# Altium schematic sheet 05 - Motor Drivers.SchDoc
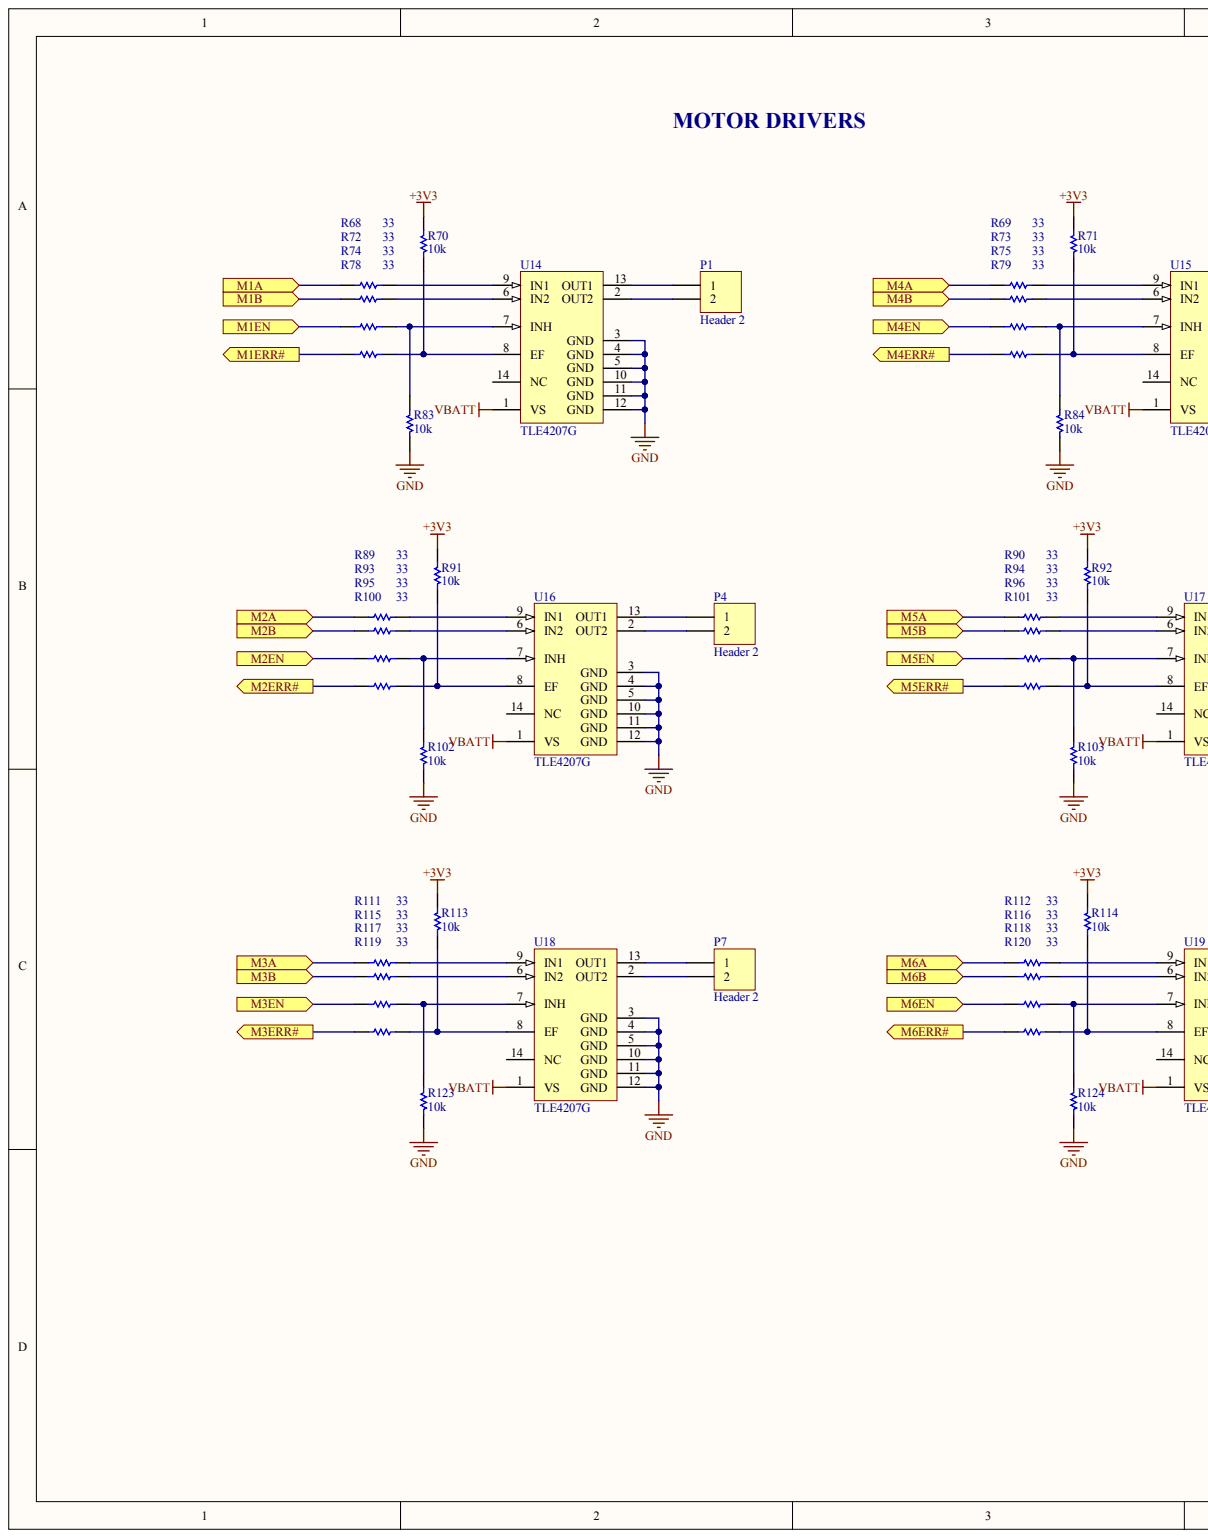
[diagram p0: 05 - Motor Drivers.SchDoc, left]
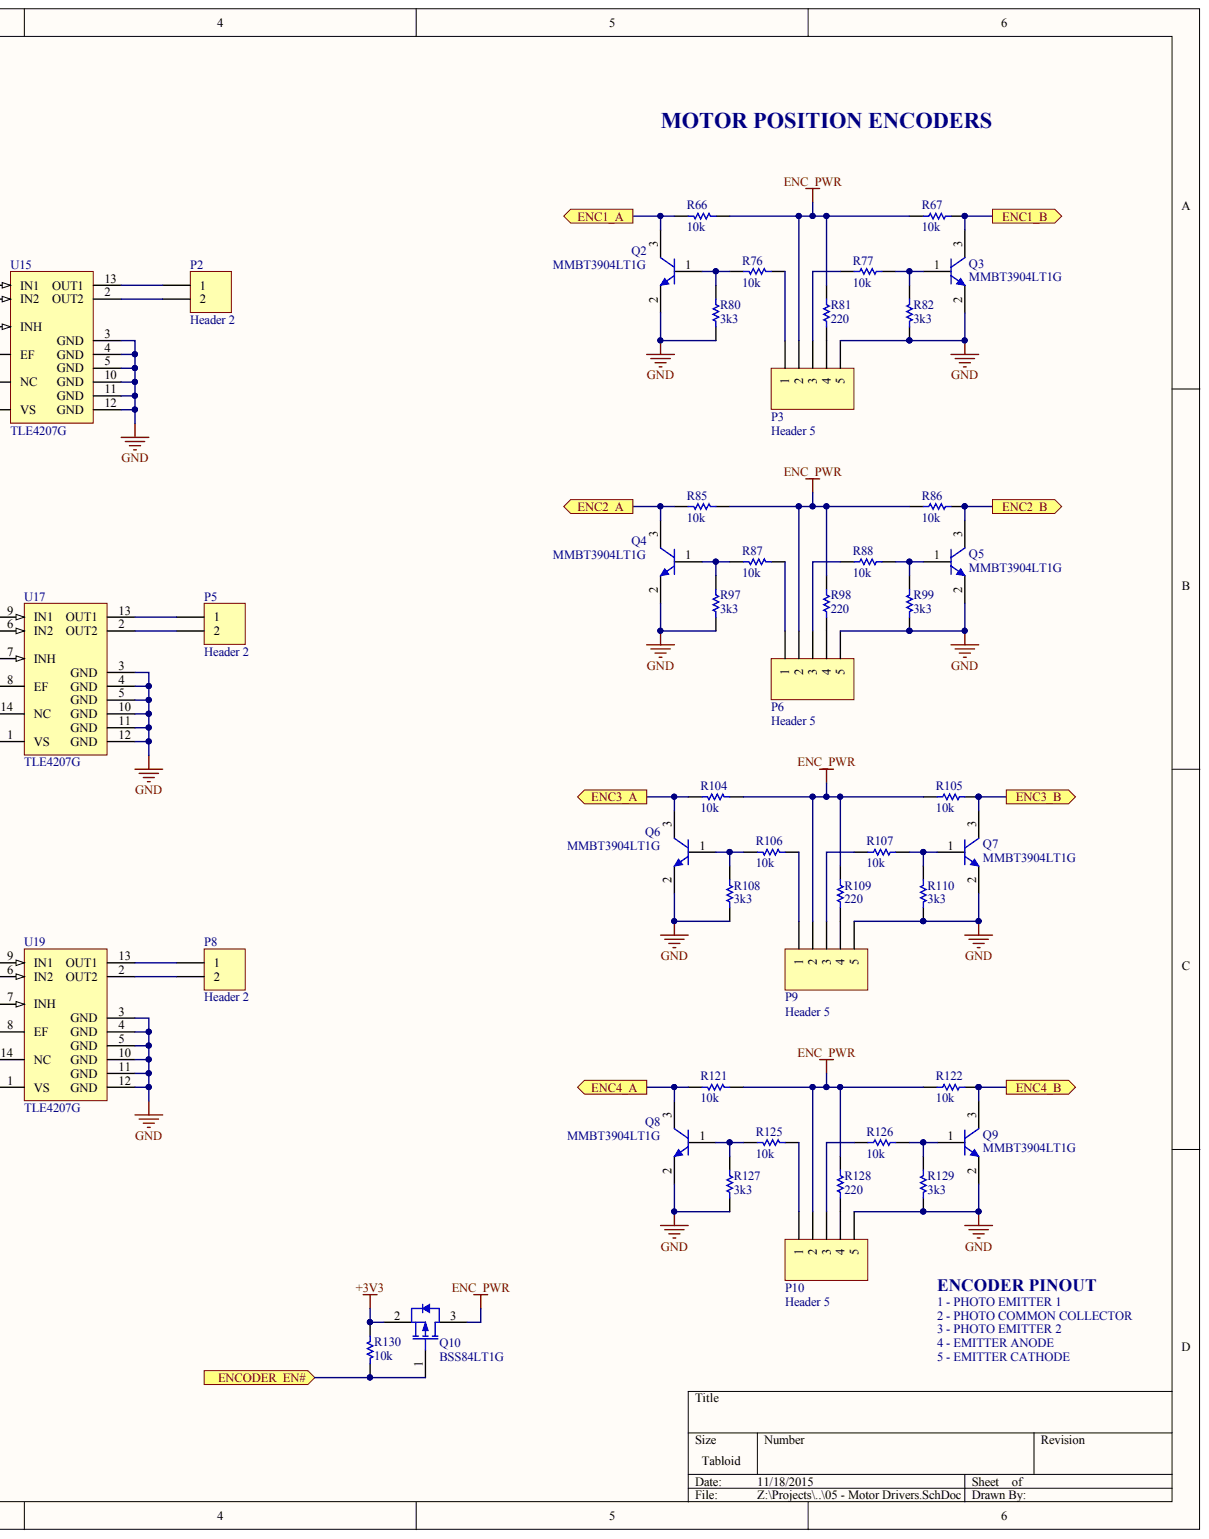
[diagram p1: 05 - Motor Drivers.SchDoc, right]

## Parts
P1  Header 2  [HDR1X2]
P2  Header 2  [HDR1X2]
P3  Header 5  [HDR1X5]
P4  Header 2  [HDR1X2]
P5  Header 2  [HDR1X2]
P6  Header 5  [HDR1X5]
P7  Header 2  [HDR1X2]
P8  Header 2  [HDR1X2]
P9  Header 5  [HDR1X5]
P10  Header 5  [HDR1X5]
Q2  MMBT3904LT1G  [ONSC-SOT-23-3-318-08_V]
Q3  MMBT3904LT1G  [ONSC-SOT-23-3-318-08_V]
Q4  MMBT3904LT1G  [ONSC-SOT-23-3-318-08_V]
Q5  MMBT3904LT1G  [ONSC-SOT-23-3-318-08_V]
Q6  MMBT3904LT1G  [ONSC-SOT-23-3-318-08_V]
Q7  MMBT3904LT1G  [ONSC-SOT-23-3-318-08_V]
Q8  MMBT3904LT1G  [ONSC-SOT-23-3-318-08_V]
Q9  MMBT3904LT1G  [ONSC-SOT-23-3-318-08_V]
Q10  BSS84LT1G  [ONSC-SOT-23-3-318-08_V]
R66  value 10k  [RESC0805(2012)_M]  (hidden comment: 10K 1% 0805(2012))
R67  value 10k  [RESC0805(2012)_M]  (hidden comment: 10K 1% 0805(2012))
R68  value 33  [RESC0805(2012)_L]  (hidden comment: 33R 1% 0805(2012))
R69  value 33  [RESC0805(2012)_L]  (hidden comment: 33R 1% 0805(2012))
R70  value 10k  [RESC0805(2012)_M]  (hidden comment: 10K 1% 0805(2012))
R71  value 10k  [RESC0805(2012)_M]  (hidden comment: 10K 1% 0805(2012))
R72  value 33  [RESC0805(2012)_L]  (hidden comment: 33R 1% 0805(2012))
R73  value 33  [RESC0805(2012)_L]  (hidden comment: 33R 1% 0805(2012))
R74  value 33  [RESC0805(2012)_L]  (hidden comment: 33R 1% 0805(2012))
R75  value 33  [RESC0805(2012)_L]  (hidden comment: 33R 1% 0805(2012))
R76  value 10k  [RESC0805(2012)_M]  (hidden comment: 10K 1% 0805(2012))
R77  value 10k  [RESC0805(2012)_M]  (hidden comment: 10K 1% 0805(2012))
R78  value 33  [RESC0805(2012)_L]  (hidden comment: 33R 1% 0805(2012))
R79  value 33  [RESC0805(2012)_L]  (hidden comment: 33R 1% 0805(2012))
R80  value 3k3  [RESC0805(2012)_L]  (hidden comment: 3K3 1% 0805(2012))
R81  value 220  [RESC0805(2012)_M]  (hidden comment: 220R 1% 0805(2012))
R82  value 3k3  [RESC0805(2012)_L]  (hidden comment: 3K3 1% 0805(2012))
R83  value 10k  [RESC0805(2012)_M]  (hidden comment: 10K 1% 0805(2012))
R84  value 10k  [RESC0805(2012)_M]  (hidden comment: 10K 1% 0805(2012))
R85  value 10k  [RESC0805(2012)_M]  (hidden comment: 10K 1% 0805(2012))
R86  value 10k  [RESC0805(2012)_M]  (hidden comment: 10K 1% 0805(2012))
R87  value 10k  [RESC0805(2012)_M]  (hidden comment: 10K 1% 0805(2012))
R88  value 10k  [RESC0805(2012)_M]  (hidden comment: 10K 1% 0805(2012))
R89  value 33  [RESC0805(2012)_L]  (hidden comment: 33R 1% 0805(2012))
R90  value 33  [RESC0805(2012)_L]  (hidden comment: 33R 1% 0805(2012))
R91  value 10k  [RESC0805(2012)_M]  (hidden comment: 10K 1% 0805(2012))
R92  value 10k  [RESC0805(2012)_M]  (hidden comment: 10K 1% 0805(2012))
R93  value 33  [RESC0805(2012)_L]  (hidden comment: 33R 1% 0805(2012))
R94  value 33  [RESC0805(2012)_L]  (hidden comment: 33R 1% 0805(2012))
R95  value 33  [RESC0805(2012)_L]  (hidden comment: 33R 1% 0805(2012))
R96  value 33  [RESC0805(2012)_L]  (hidden comment: 33R 1% 0805(2012))
R97  value 3k3  [RESC0805(2012)_L]  (hidden comment: 3K3 1% 0805(2012))
R98  value 220  [RESC0805(2012)_M]  (hidden comment: 220R 1% 0805(2012))
R99  value 3k3  [RESC0805(2012)_L]  (hidden comment: 3K3 1% 0805(2012))
R100  value 33  [RESC0805(2012)_L]  (hidden comment: 33R 1% 0805(2012))
R101  value 33  [RESC0805(2012)_L]  (hidden comment: 33R 1% 0805(2012))
R102  value 10k  [RESC0805(2012)_M]  (hidden comment: 10K 1% 0805(2012))
R103  value 10k  [RESC0805(2012)_M]  (hidden comment: 10K 1% 0805(2012))
R104  value 10k  [RESC0805(2012)_M]  (hidden comment: 10K 1% 0805(2012))
R105  value 10k  [RESC0805(2012)_M]  (hidden comment: 10K 1% 0805(2012))
R106  value 10k  [RESC0805(2012)_M]  (hidden comment: 10K 1% 0805(2012))
R107  value 10k  [RESC0805(2012)_M]  (hidden comment: 10K 1% 0805(2012))
R108  value 3k3  [RESC0805(2012)_L]  (hidden comment: 3K3 1% 0805(2012))
R109  value 220  [RESC0805(2012)_M]  (hidden comment: 220R 1% 0805(2012))
R110  value 3k3  [RESC0805(2012)_L]  (hidden comment: 3K3 1% 0805(2012))
R111  value 33  [RESC0805(2012)_L]  (hidden comment: 33R 1% 0805(2012))
R112  value 33  [RESC0805(2012)_L]  (hidden comment: 33R 1% 0805(2012))
R113  value 10k  [RESC0805(2012)_M]  (hidden comment: 10K 1% 0805(2012))
R114  value 10k  [RESC0805(2012)_M]  (hidden comment: 10K 1% 0805(2012))
R115  value 33  [RESC0805(2012)_L]  (hidden comment: 33R 1% 0805(2012))
R116  value 33  [RESC0805(2012)_L]  (hidden comment: 33R 1% 0805(2012))
R117  value 33  [RESC0805(2012)_L]  (hidden comment: 33R 1% 0805(2012))
R118  value 33  [RESC0805(2012)_L]  (hidden comment: 33R 1% 0805(2012))
R119  value 33  [RESC0805(2012)_L]  (hidden comment: 33R 1% 0805(2012))
R120  value 33  [RESC0805(2012)_L]  (hidden comment: 33R 1% 0805(2012))
R121  value 10k  [RESC0805(2012)_M]  (hidden comment: 10K 1% 0805(2012))
R122  value 10k  [RESC0805(2012)_M]  (hidden comment: 10K 1% 0805(2012))
R123  value 10k  [RESC0805(2012)_M]  (hidden comment: 10K 1% 0805(2012))
R124  value 10k  [RESC0805(2012)_M]  (hidden comment: 10K 1% 0805(2012))
R125  value 10k  [RESC0805(2012)_M]  (hidden comment: 10K 1% 0805(2012))
R126  value 10k  [RESC0805(2012)_M]  (hidden comment: 10K 1% 0805(2012))
R127  value 3k3  [RESC0805(2012)_L]  (hidden comment: 3K3 1% 0805(2012))
R128  value 220  [RESC0805(2012)_M]  (hidden comment: 220R 1% 0805(2012))
R129  value 3k3  [RESC0805(2012)_L]  (hidden comment: 3K3 1% 0805(2012))
R130  value 10k  [RESC0805(2012)_M]  (hidden comment: 10K 1% 0805(2012))
U14  TLE4207G  [INF-PG-DSO-14-22_N]
U15  TLE4207G  [INF-PG-DSO-14-22_N]
U16  TLE4207G  [INF-PG-DSO-14-22_N]
U17  TLE4207G  [INF-PG-DSO-14-22_N]
U18  TLE4207G  [INF-PG-DSO-14-22_N]
U19  TLE4207G  [INF-PG-DSO-14-22_N]

## Ports
ENC1_A, ENC1_B, ENC2_A, ENC2_B, ENC3_A, ENC3_B, ENC4_A, ENC4_B, ENCODER_EN#, M1A, M1B, M1EN, M1ERR#, M2A, M2B, M2EN, M2ERR#, M3A, M3B, M3EN, M3ERR#, M4A, M4B, M4EN, M4ERR#, M5A, M5B, M5EN, M5ERR#, M6A, M6B, M6EN, M6ERR#

## Nets
+3V3: Q10.2 R70.1 R71.1 R91.1 R92.1 R113.1 R114.1 R130.1
ENC_PWR: P3.2 P6.2 P9.2 P10.2 Q10.3 R66.2 R67.1 R81.2 R85.2 R86.1 R98.2 R104.2 R105.1 R109.2 R121.2 R122.1 R128.2
GND: P3.5 P6.5 P9.5 P10.5 Q2.2 Q3.2 Q4.2 Q5.2 Q6.2 Q7.2 Q8.2 Q9.2 R80.2 R82.2 R83.1 R84.1 R97.2 R99.2 R102.1 R103.1 R108.2 R110.2 R123.1 R124.1 R127.2 R129.2 U14.3(GND) U14.4(GND) U14.5(GND) U14.10(GND) U14.11(GND) U14.12(GND) U15.3(GND) U15.4(GND) U15.5(GND) U15.10(GND) U15.11(GND) U15.12(GND) U16.3(GND) U16.4(GND) U16.5(GND) U16.10(GND) U16.11(GND) U16.12(GND) U17.3(GND) U17.4(GND) U17.5(GND) U17.10(GND) U17.11(GND) U17.12(GND) U18.3(GND) U18.4(GND) U18.5(GND) U18.10(GND) U18.11(GND) U18.12(GND) U19.3(GND) U19.4(GND) U19.5(GND) U19.10(GND) U19.11(GND) U19.12(GND)
VBATT: U14.1(VS) U15.1(VS) U16.1(VS) U17.1(VS) U18.1(VS) U19.1(VS)
ENC1_A: Q2.3 R66.1 ; port ENC1_A
ENC1_B: Q3.3 R67.2 ; port ENC1_B
ENC2_A: Q4.3 R85.1 ; port ENC2_A
ENC2_B: Q5.3 R86.2 ; port ENC2_B
ENC3_A: Q6.3 R104.1 ; port ENC3_A
ENC3_B: Q7.3 R105.2 ; port ENC3_B
ENC4_A: Q8.3 R121.1 ; port ENC4_A
ENC4_B: Q9.3 R122.2 ; port ENC4_B
ENCODER_EN#: Q10.1 R130.2 ; port ENCODER_EN#
M1A: R68.1 ; port M1A
M1B: R72.1 ; port M1B
M1EN: R74.1 ; port M1EN
M1ERR#: R78.1 ; port M1ERR#
M2A: R89.1 ; port M2A
M2B: R93.1 ; port M2B
M2EN: R95.1 ; port M2EN
M2ERR#: R100.1 ; port M2ERR#
M3A: R111.1 ; port M3A
M3B: R115.1 ; port M3B
M3EN: R117.1 ; port M3EN
M3ERR#: R119.1 ; port M3ERR#
M4A: R69.1 ; port M4A
M4B: R73.1 ; port M4B
M4EN: R75.1 ; port M4EN
M4ERR#: R79.1 ; port M4ERR#
M5A: R90.1 ; port M5A
M5B: R94.1 ; port M5B
M5EN: R96.1 ; port M5EN
M5ERR#: R101.1 ; port M5ERR#
M6A: R112.1 ; port M6A
M6B: R116.1 ; port M6B
M6EN: R118.1 ; port M6EN
M6ERR#: R120.1 ; port M6ERR#
NetP1_1: P1.1 U14.13(OUT1)
NetP1_2: P1.2 U14.2(OUT2)
NetP2_1: P2.1 U15.13(OUT1)
NetP2_2: P2.2 U15.2(OUT2)
NetP3_1: P3.1 R76.1
NetP3_3: P3.3 R77.1
NetP3_4: P3.4 R81.1
NetP4_1: P4.1 U16.13(OUT1)
NetP4_2: P4.2 U16.2(OUT2)
NetP5_1: P5.1 U17.13(OUT1)
NetP5_2: P5.2 U17.2(OUT2)
NetP6_1: P6.1 R87.1
NetP6_3: P6.3 R88.1
NetP6_4: P6.4 R98.1
NetP7_1: P7.1 U18.13(OUT1)
NetP7_2: P7.2 U18.2(OUT2)
NetP8_1: P8.1 U19.13(OUT1)
NetP8_2: P8.2 U19.2(OUT2)
NetP9_1: P9.1 R106.1
NetP9_3: P9.3 R107.1
NetP9_4: P9.4 R109.1
NetP10_1: P10.1 R125.1
NetP10_3: P10.3 R126.1
NetP10_4: P10.4 R128.1
NetQ2_1: Q2.1 R76.2 R80.1
NetQ3_1: Q3.1 R77.2 R82.1
NetQ4_1: Q4.1 R87.2 R97.1
NetQ5_1: Q5.1 R88.2 R99.1
NetQ6_1: Q6.1 R106.2 R108.1
NetQ7_1: Q7.1 R107.2 R110.1
NetQ8_1: Q8.1 R125.2 R127.1
NetQ9_1: Q9.1 R126.2 R129.1
NetR68_2: R68.2 U14.9(IN1)
NetR69_2: R69.2 U15.9(IN1)
NetR70_2: R70.2 R78.2 U14.8(EF)
NetR71_2: R71.2 R79.2 U15.8(EF)
NetR72_2: R72.2 U14.6(IN2)
NetR73_2: R73.2 U15.6(IN2)
NetR74_2: R74.2 R83.2 U14.7(INH)
NetR75_2: R75.2 R84.2 U15.7(INH)
NetR89_2: R89.2 U16.9(IN1)
NetR90_2: R90.2 U17.9(IN1)
NetR91_2: R91.2 R100.2 U16.8(EF)
NetR92_2: R92.2 R101.2 U17.8(EF)
NetR93_2: R93.2 U16.6(IN2)
NetR94_2: R94.2 U17.6(IN2)
NetR95_2: R95.2 R102.2 U16.7(INH)
NetR96_2: R96.2 R103.2 U17.7(INH)
NetR111_2: R111.2 U18.9(IN1)
NetR112_2: R112.2 U19.9(IN1)
NetR113_2: R113.2 R119.2 U18.8(EF)
NetR114_2: R114.2 R120.2 U19.8(EF)
NetR115_2: R115.2 U18.6(IN2)
NetR116_2: R116.2 U19.6(IN2)
NetR117_2: R117.2 R123.2 U18.7(INH)
NetR118_2: R118.2 R124.2 U19.7(INH)

Not connected: U14 14(NC); U15 14(NC); U16 14(NC); U17 14(NC); U18 14(NC); U19 14(NC)

## Library data of the parts
P1 P2 P4 P5 P7 P8 = Header 2: Header, 2-Pin; Component Kind: Standard; LatestRevisionDate: 17-Jul-2002; LatestRevisionNote: Re-released for DXP Platform.; Library Name: Miscellaneous Connectors.IntLib; Signal Integrity: Connector; Publisher: Altium Limited
P3 P6 P9 P10 = Header 5: Header, 5-Pin; Component Kind: Standard; LatestRevisionDate: 17-Jul-2002; LatestRevisionNote: Re-released for DXP Platform.; Library Name: Miscellaneous Connectors.IntLib; Signal Integrity: Connector; Publisher: Altium Limited
Q2-Q9 = CMP-1048-00631-1: General Purpose Transistor, NPN Silicon, 3-Pin SOT-23, Pb-Free, Tape and Reel; Manufacturer: On Semiconductor; fT Min (MHz): 300; IC Continuous (A): 0.2; Component Kind: Standard; ComponentLink1Description: Manufacturer URL; ComponentLink1URL: http://www.onsemi.com/; ComponentLink2Description: Datasheet; ComponentLink2URL: http://www.onsemi.cn/pub_link/Collateral/MMBT3904LT1-D.PDF; ComponentLink3Description: Package Specification; ComponentLink3URL: http://www.onsemi.cn/pub_link/Collateral/318-08.PDF; DatasheetVersion: Rev. 12; Library Name: Altium Content Vault; Mounting Technology: Surface Mount; PackageDescription: 3-Pin Small Outline Transistor, Body 2.92 x 1.3 mm, Pitch 0.95 mm; PackageReference: SOT-23-3-318-08; PackageVersion: Rev. AP, 11/2009; Packing: Tape and Reel; PartNumber: MMBT3904LT1G; Polarity: NPN; RoHS: TRUE
Q10 = CMP-1058-00762-1: Power MOSFET Single P-Channel, -50 V, 10 Ohm, 3-Pin SOT-23, Pb-Free, Tape and Reel; Manufacturer: On Semiconductor; Channel Polarity: P Channel; Ciss Typ (pF): 36; Coss Typ (pF): 17; Crss Typ (pF): 6.5; ID Max (A): 0.13; PD Max (W): 0.225; V(BR)DSS Min (V): 50; VGS(th) Max (V): 2; VGS Max (V): 20; Component Kind: Standard; ComponentLink1Description: Manufacturer URL; ComponentLink1URL: http://www.onsemi.com/; ComponentLink2Description: Datasheet; ComponentLink2URL: http://www.onsemi.com/pub_link/Collateral/BSS84LT1-D.PDF; ComponentLink3Description: Package Specification; ComponentLink3URL: http://www.onsemi.cn/pub_link/Collateral/318-08.PDF; Configuration: Single; DatasheetVersion: Rev. 8; Library Name: Altium Inc., Content Vault; Mounting Technology: Surface Mount; PackageDescription: 3-Pin Small Outline Transistor, Body 2.92 x 1.3 mm, Pitch 0.95 mm; PackageReference: SOT-23-3-318-08; Package Type: SOT-23-3; PackageVersion: Rev. AP, 11/2009; Packing: Tape and Reel; PartNumber: BSS84LT1G; RoHS: Pb-Free
R66 R67 R70 R71 R76 R77 R83-R88 R91 R92 R102-R107 R113 R114 R121-R126 R130 = CMP-1013-00623-1: 10K 0.125W 1% 0805 (2012 Metric)  SMD; Case-EIA: 0805; Case-Metric: 2012; Component Kind: Standard; Library Name: Altium Content Vault; Power: 0.125 W; Technology: SMT; Tolerance: 1 %
R68 R69 R72-R75 R78 R79 R89 R90 R93-R96 R100 R101 R111 R112 R115-R120 = CMP-1013-00341-1: 33R 0.125W 1% 0805 (2012 Metric)  SMD; Case-EIA: 0805; Case-Metric: 2012; Component Kind: Standard; Library Name: Altium Content Vault; Power: 0.125 W; Technology: SMT; Tolerance: 1 %
R80 R82 R97 R99 R108 R110 R127 R129 = CMP-1013-00567-1: 3K3 0.125W 1% 0805 (2012 Metric)  SMD; Case-EIA: 0805; Case-Metric: 2012; Component Kind: Standard; Library Name: Altium Content Vault; Power: 0.125 W; Technology: SMT; Tolerance: 1 %
R81 R98 R109 R128 = CMP-1013-00433-1: 220R 0.125W 1% 0805 (2012 Metric)  SMD; Case-EIA: 0805; Case-Metric: 2012; Component Kind: Standard; Library Name: Altium Content Vault; Power: 0.125 W; Technology: SMT; Tolerance: 1 %
U14-U19 = CMP-1114-00001-1: 1 A Dual-HBD (Dual-Half-Bridge Driver), -40 to 150 degC, PG-DSO-14-22, Reel, Green; Manufacturer: Infineon; Green: TRUE; Group: Multi Half Bridges; IPeak: 2 x 1 A; Iq: 2 x 0.8 A; Iq (typ): 20 uA; Protect: short circuit e.a.; Component Kind: Standard; ComponentLink1Description: Manufacturer URL; ComponentLink1URL: http://www.infineon.com/; ComponentLink2Description: Datasheet; ComponentLink2URL: http://www.infineon.com/dgdl/DS_TLE4207G_V11_2008-01-08_Green.pdf?folderId=db3a3043156fd5730116144c5d101c30&fileId=db3a30431c48a312011c4b7917e47fd0&location=en.Products.Automotive_ICs.Motor_Drivers__Automotive_.Integrated_Smart_Power_Motor_Drivers.DATASHEE; ComponentLink3Description: Package Specification; ComponentLink3URL: http://www.infineon.com/dgdl/DS_TLE4206G_2007-08-10_Green_V11.pdf?folderId=db3a3043156fd5730116144c5d101c30&fileId=db3a3043163797a6011685bdd91c1672&sId=db3a30433d68e984013d7e2ab9f06173; DatasheetVersion: Rev. 1.1; Halogen-free: TRUE; Inhibit: TRUE; Library Name: Altium Content Vault; Mounting Technology: Surface Mount; PackageDescription: 14-Pin Plastic (Green) Dual Small Outline Package, 8.65 x 3.9 mm Body, 1.27 mm Pitch; PackageReference: PG-DSO-14-22; PackageVersion: Aug-07; Packing: Reel; PartNumber: TLE4207G; Technology: Bipolar
